# Altium schematic sheet microcontroller&outputdispay.SchDoc
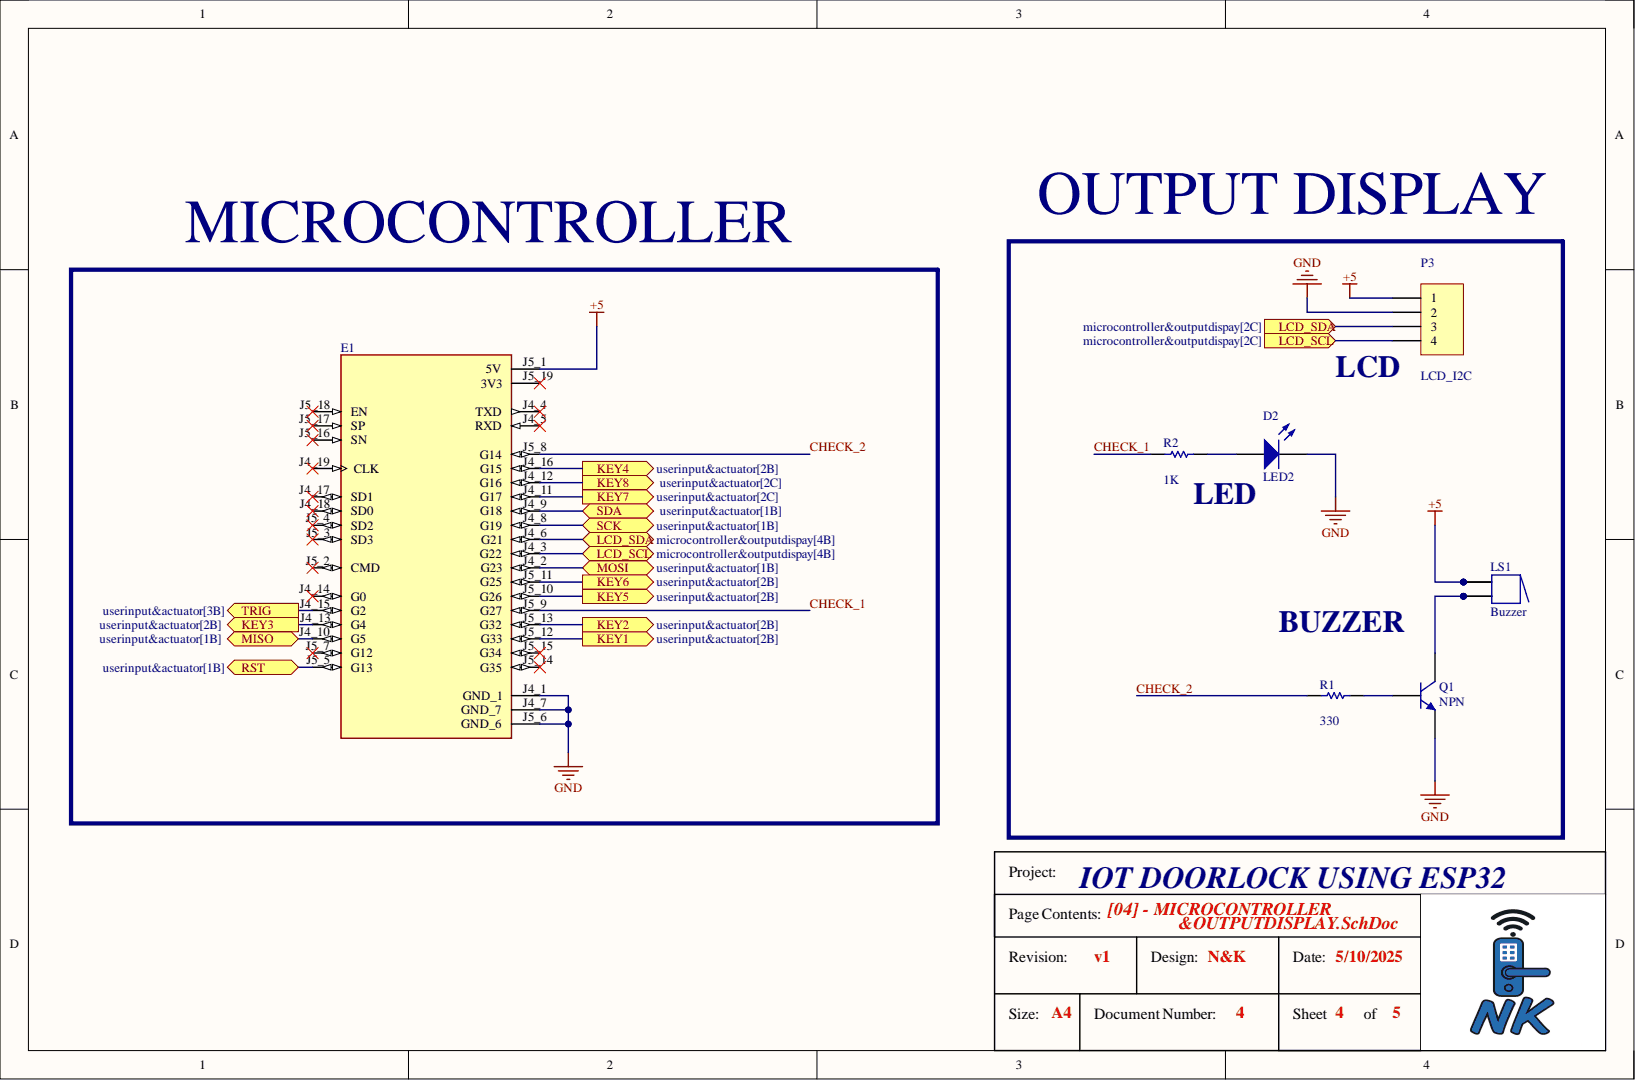
[diagram p0: microcontroller&outputdispay.SchDoc]

## Parts
D2  LED2  [3.2X1.6X1.1]
E1  (no value)  [MODULE_ESP32_NODEMCU]
LS1  Buzzer  [ABSM-1574]
P3  LCD_I2C  [HDR1X4]
Q1  NPN  [TO-226-AA]
R1  value 330  [AXIAL-0.3]  (hidden comment: Res1)
R2  value 1K  [AXIAL-0.3]  (hidden comment: Res1)

## Ports
KEY1, KEY2, KEY3, KEY4, KEY5, KEY6, KEY7, KEY8, LCD_SCL, LCD_SDA, MISO, MOSI, RST, SCK, SDA, TRIG

## Nets
+5: E1.J5_1(5V) LS1.1 LS1.1A P3.1
GND: D2.2 E1.J4_1(GND_1) E1.J4_7(GND_7) E1.J5_6(GND_6) P3.2 Q1.3
CHECK_1: E1.J5_9(G27) R2.1
CHECK_2: E1.J5_8(G14) R1.1
KEY1: E1.J5_12(G33) ; port KEY1
KEY2: E1.J5_13(G32) ; port KEY2
KEY3: E1.J4_13(G4) ; port KEY3
KEY4: E1.J4_16(G15) ; port KEY4
KEY5: E1.J5_10(G26) ; port KEY5
KEY6: E1.J5_11(G25) ; port KEY6
KEY7: E1.J4_11(G17) ; port KEY7
KEY8: E1.J4_12(G16) ; port KEY8
LCD_SCL: E1.J4_3(G22) P3.4 ; port LCD_SCL
LCD_SDA: E1.J4_6(G21) P3.3 ; port LCD_SDA
MISO: E1.J4_10(G5) ; port MISO
MOSI: E1.J4_2(G23) ; port MOSI
RST: E1.J5_5(G13) ; port RST
SCK: E1.J4_8(G19) ; port SCK
SDA: E1.J4_9(G18) ; port SDA
TRIG: E1.J4_15(G2) ; port TRIG
NetD2_1: D2.1 R2.2
NetLS1_2: LS1.2 LS1.2A Q1.1
NetQ1_2: Q1.2 R1.2

Not connected: E1 J4_4(TXD) J4_5(RXD) J4_14(G0) J4_17(SD1) J4_18(SD0) J4_19(CLK) J5_2(CMD) J5_3(SD3) J5_4(SD2) J5_7(G12) J5_14(G35) J5_15(G34) J5_16(SN) J5_17(SP) J5_18(EN) J5_19(3V3)

## Library data of the parts
D2 = LED2: Typical RED, GREEN, YELLOW, AMBER GaAs LED; Component Kind: Standard; LatestRevisionDate: 17-Jul-2002; LatestRevisionNote: Re-released for DXP Platform.; Library Name: Miscellaneous Devices.SchLib; PackageDescription: SM LED; 2 Flat Leads; PackageReference: 3.2X1.6X1.1; PackageVersion: Feb-2002; Published: 8-Jun-2000; Simulation: LED2; Publisher: Altium Limited
E1 = ESP32_NODEMCU: NodeMCU is an open source loT platform. ESP32 is a series of low cost, low powersystem-on-chip (SoC) microcontrollers with integrated Wi-Fi and dual-mode Bluetooth.; MF: AZ Delivery; MP: ESP32 NODEMCU; Check_prices: https://www.snapeda.com/parts/ESP32%20NODEMCU/AZ+Displays/view-part/?ref=eda; Component Kind: Standard; Library Name: ESP32_NODEMCU.SchLib; Package: Package; SnapEDA_Link: https://www.snapeda.com/parts/ESP32%20NODEMCU/AZ+Displays/view-part/?ref=snap
LS1 = Buzzer: Magnetic Transducer Buzzer; Component Kind: Standard; ComponentLink1Description: Manufacturer Link; ComponentLink1URL: http://www.megastar.com/linecard/buzzmode/index.php; DatasheetVersion: 17-Dec-2003; LatestRevisionDate: 17-Dec-2003; LatestRevisionNote: Schematic revised, PCB Footprint replaced.; Library Name: Miscellaneous Devices.SchLib; PackageDescription: Magnetic Transducer Buzzer; PackageReference: ABSM-1574; Published: 24-Mar-1999; Publisher: Altium Limited
P3 = Header 4: Header, 4-Pin; Component Kind: Standard; LatestRevisionDate: 17-Jul-2002; LatestRevisionNote: Re-released for DXP Platform.; Library Name: Miscellaneous Connectors.SchLib; Signal Integrity: Connector; Publisher: Altium Limited
Q1 = NPN: NPN Bipolar Transistor; Code_JEDEC: TO-226-AA; Component Kind: Standard; LatestRevisionDate: 17-Jul-2002; LatestRevisionNote: Re-released for DXP Platform.; Library Name: Miscellaneous Devices.SchLib; PackageDescription: TO, Flat Index; 3 In-Line, Axial Leads; Body Dia. 4.8mm; Leads 0.55 x 0.51 mm (max); PackageReference: TO-226-AA; Published: 8-Jun-2000; Signal Integrity: NPN; Simulation: NPN; Publisher: Altium Limited
R1 R2 = Res1: Resistor; Component Kind: Standard; LatestRevisionDate: 17-Jul-2002; LatestRevisionNote: Re-released for DXP Platform.; Library Name: Miscellaneous Devices.SchLib; PackageDescription: Axial Device, Thru-Hole; 2 Leads; 0.3 in Pin Spacing; PackageReference: AXIAL-0.3; Published: 8-Jun-2000; Signal Integrity: Res; Simulation: RESISTOR; Publisher: Altium Limited
